# Altium schematic sheet Smart Dustbin.SchDoc
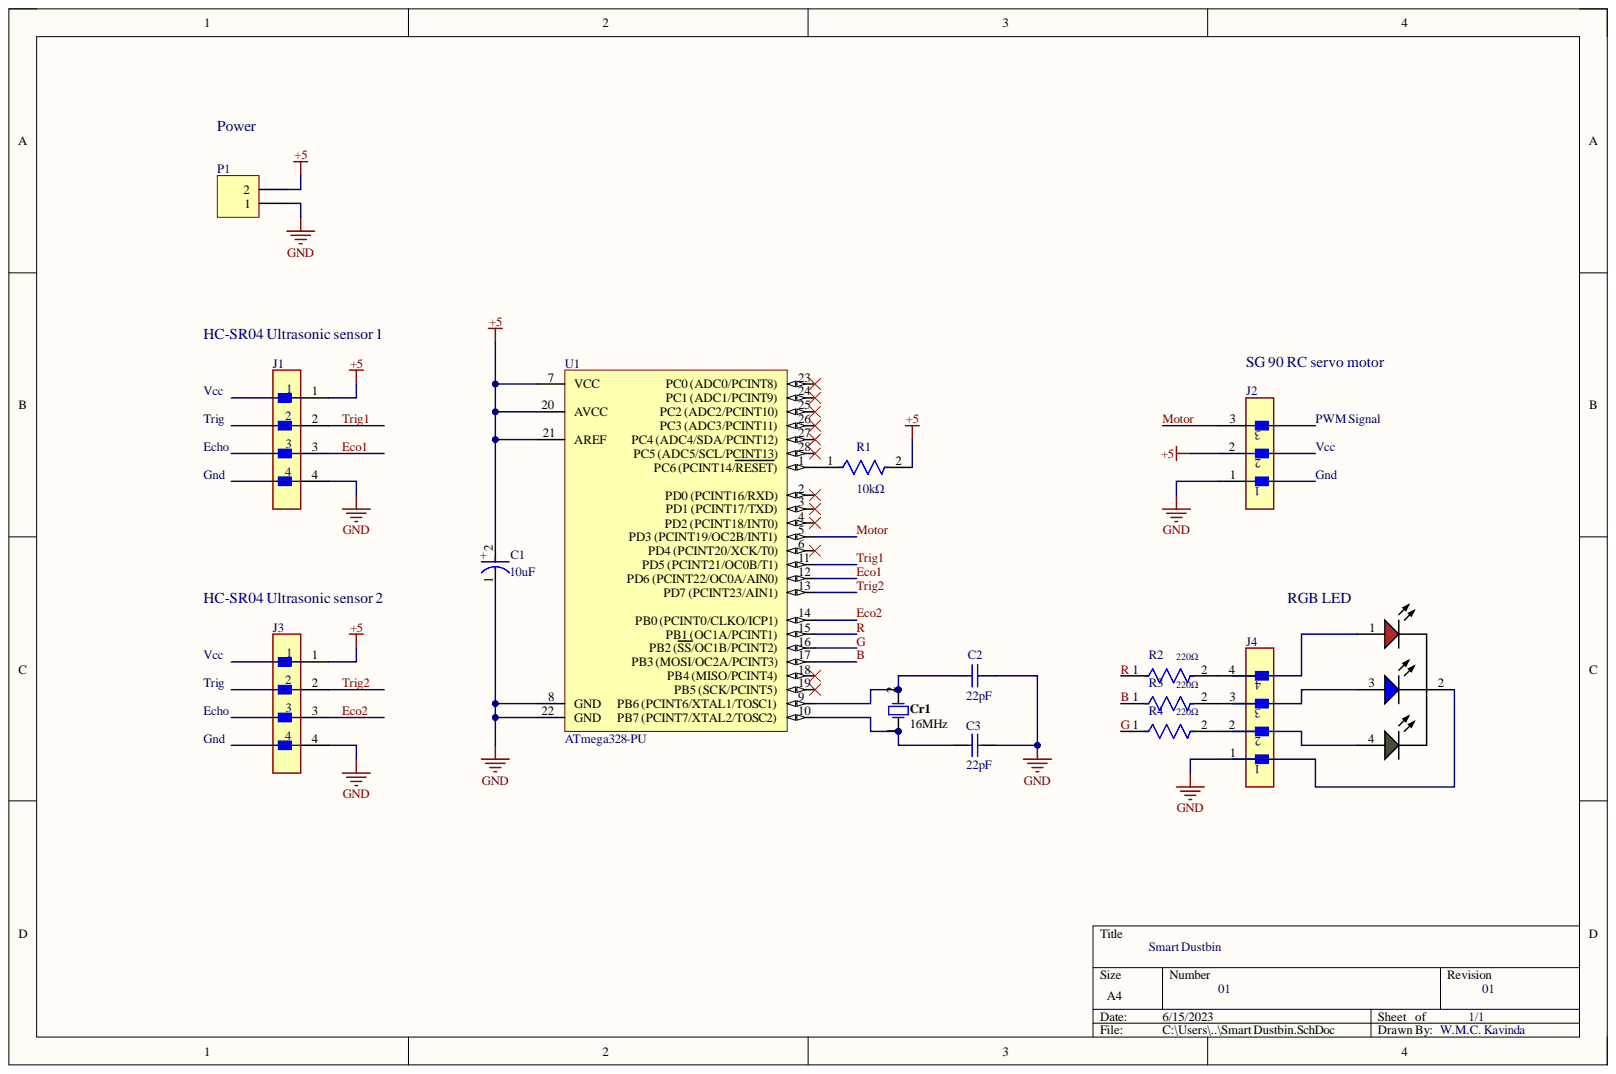
[diagram p0: Smart Dustbin.SchDoc]

## Parts
C1  10uF  [FP-RAD-TH-D_8_0_5-L_11_5-MFG]
C2  22pF  [KEMT-C315-THT-2]
C3  22pF  [KEMT-C315-THT-2]
Cr1  16MHz  [FOX-FOXSLF160-20]
DS1  WP154A4SUREQBFZGC  [KING-WP154A4SUREQBFZGC_V]  (value not drawn)
J1  TMM-104-01-S-S  [FP-TMM-104-01-S-S-MFG]  (value not drawn)
J2  TMM-103-03-T-S  [FP-TMM-103-03-T-S-MFG]  (value not drawn)
J3  TMM-104-01-S-S  [FP-TMM-104-01-S-S-MFG]  (value not drawn)
J4  TMM-104-01-S-S  [FP-TMM-104-01-S-S-MFG]  (value not drawn)
P1  Header 2  [HDR1X2]  (value not drawn)
R1  value 10kΩ  Resistance: 10kΩ  [FP-CF18-MFG]  (hidden comment: 10K)
R2  220Ω  [FP-MBA0204-MFG]
R3  220Ω  [FP-MBA0204-MFG]
R4  220Ω  [FP-MBA0204-MFG]
U1  ATmega328-PU  [28P3]

## Nets
+5: C1.2 J1.1 J2.2 J3.1 P1.2 R1.2 U1.7(VCC) U1.20(AVCC) U1.21(AREF)
GND: C1.1 C2.2 C3.2 J1.4 J2.1 J3.4 J4.1 P1.1 U1.8(GND) U1.22(GND)
B: R3.1 U1.17(PB3_(MOSI/OC2A/PCINT3))
Eco1: J1.3 U1.12(PD6_(PCINT22/OC0A/AIN0))
Eco2: J3.3 U1.14(PB0_(PCINT0/CLKO/ICP1))
G: R4.1 U1.16(PB2_(SS/OC1B/PCINT2))
Motor: J2.3 U1.5(PD3_(PCINT19/OC2B/INT1))
R: R2.1 U1.15(PB1_(OC1A/PCINT1))
Trig1: J1.2 U1.11(PD5_(PCINT21/OC0B/T1))
Trig2: J3.2 U1.13(PD7_(PCINT23/AIN1))
NetC2_1: C2.1 Cr1.2 U1.9
NetC3_1: C3.1 Cr1.1 U1.10
NetJ4_2: J4.2 R4.2
NetJ4_3: J4.3 R3.2
NetJ4_4: J4.4 R2.2
NetR1_1: R1.1 U1.1(PC6_(PCINT14/RESET))

Not connected: DS1 1 2 3 4; U1 2(PD0_(PCINT16/RXD)) 3(PD1_(PCINT17/TXD)) 4(PD2_(PCINT18/INT0)) 6(PD4_(PCINT20/XCK/T0)) 18(PB4_(MISO/PCINT4)) 19(PB5_(SCK/PCINT5)) 23(PC0_(ADC0/PCINT8)) 24(PC1_(ADC1/PCINT9)) 25 26(PC3_(ADC3/PCINT11)) 27 28(PC5_(ADC5/SCL/PCINT13))

## Library data of the parts
C1 = CMP-05427-000566-1: Aluminum Electrolytic Capacitors (Radial Lead Type) AEC-Q200 Qualified 10uF ±20% 50V; ESR (Equivalent Series Resistance): 950mR; Lead Diameter: 0.6mm; Life (Hours): 2000Hour; Ripple Current: 180mA; Capacitance: 10uF; Case/Package: Radial; Component Kind: Standard; Construction: RADIAL; Diameter: 8mm; Height: 11.5mm; Height - Seated (Max): 0.492inch; Impedance: 950mR; Lead Pitch: 3.5mm; Length: 11.5mm; Library Name: Altium Content Vault; Max Operating Temperature: 125°C; Min Operating Temperature: -40°C; Mount: Through Hole; Number of Pins: 2; Package Quantity: 200; Packaging: Bulk; Radiation Hardening: No; REACH SVHC: No SVHC; RoHS Compliant: Yes; Series: TA; Termination: Radial; Tolerance: 20%; Voltage Rating: 50V; Voltage Rating (DC): 50V
C2 C3 = CMP-1664-00008-1: Capacitor, Ceramic, 22 pF, +/-10 %, 100 V, -55 to 125 degC, 2-Pin THD, RoHS, Bulk; Case\Package: TO-220; Max Input Voltage: 40 V; Max Ouput Current: 1.5 A; Min Input Voltage: 4.2 V; Output Voltage: 1.2 V; Outputs: 1; Component Kind: Standard; ComponentLink1Description: Manufacturer URL; ComponentLink1URL: http://www.kemet.com/; ComponentLink2Description: Datasheet; ComponentLink2URL: http://capacitoredge.kemet.com/capedge2/DataSheet/Datasheet-C315C220K1G5TA.pdf?pn=C315C220K1G5TA{BULK}; DatasheetVersion: Aug-16; Height: 9.28 mm; Length: 10.28 mm; Library Name: Altium Content Vault; Max Operating Temperature: 125 degC; Min Operating Temperature: 0 degC; Mount: Through Hole; Output Current: 1.5 A; Package Quantity: 50; PackageDescription: 2-Pin Through Hole Device, Body 3.81 x 2.54 mm; PackageReference: C315-THT-2; Packaging: Rail/Tube; Pins: 3; Radiation Hardening: No; REACH SVHC: No SVHC; RoHSCompliant: true; Weight: 0.08113 oz; Width: 4.82 mm
Cr1 = CMP-1749-00003-1: Resistance Weld Thru-Hole Crystal, 16 MHz, -20 to 70 degC, 2-Pin THD, RoHS, Bulk; Case\Package: Axial; Power Rating: 250 mW; Resistance: 221 kohm; Tolerance: 1%; Voltage Rating: 250 V; Component Kind: Standard; ComponentLink1Description: Manufacturer URL; ComponentLink1URL: http://www.foxonline.com/; ComponentLink2Description: Datasheet; ComponentLink2URL: http://www.foxonline.com/pdfs/hc49slf.pdf; DatasheetVersion: Mar-15; Length: 0.248 inch; Library Name: Altium Content Vault; Max Operating Temperature: 155 degC; Min Operating Temperature: -55 degC; Mount: Through Hole; Package Quantity: 200; PackageDescription: 2-Pin Through Hole Device, Body 11.35 x 4.65 mm; PackageReference: FOXSLF160-20; Packaging: Bulk; RoHSCompliant: true
DS1 = CMP-2000-07415-1: Full Color LED Lamp, Dominant Wavelength RGB 630 / 525 / 465 nm, -40 to 85 degC, 4-Pin THD, RoHS; Manufacturer: Kingbright; 3D Model: WP154A4SUREQBFZGW.sldprt    WP154A4SUREQBFZGW.pro    WP154A4SUREQBFZGW.igs    WP154A4SUREQBFZGW.stp; Color: Red,Green,Blue(RGB); Current - Test: 20mA; Lens Style/Size: RoundwithDomedTop,5mm,T-13/4; Lens Type: Clear; Millicandela Rating: 1300mcdRed,1700mcdGreen,900mcdBlue; Online Catalog: 5mm,T-13/4(RGB)RoundwithDomedTopLEDLamp; Other Names: 754-1615  WP154A4SUREQBFZGC-ND; Viewing Angle: 50°; Voltage - Forward (Vf) (Typ): 1.9VRed,3.3VGreen,3.3VBlue; Wavelength - Dominant: 630nm,525nm,465nm; Wavelength - Peak: 645nm,515nm,460nm; Category: Optoelectronics; Component Kind: Standard; Datasheet URL: https://www.kingbrightusa.com/images/catalog/spec/WP154A4SUREQBFZGC.pdf; Datasheet Version: Rev. 5A, 08/2014; Family: LEDIndication-Discrete; Height: 8.60mm; Library Name: Altium Content Vault; Manufacturer URL: http://www.kingbright.com/; Mounting Technology: ThroughHole; Mounting Type: ThroughHole; Package / Case: Radial-4Leads; Package Description: 4-Pin Through Hole LED, Dia 5.9 mm, Pitch 1.27 mm; Package Reference: WP154A4SUREQBFZGC; Packaging: Bulk; Standard Package: 500
J1 J3 J4 = CMP-02766-001674-1: CONN HEADER VERT 4POS 2MM; Connector Type: Male Pin; Gender: Male; Max Current Rating: 3.9A; Max Voltage Rating (AC): 500V; Number of Contacts: 4; Color: Black; Component Kind: Standard; Contact Material: Bronze; Contact Mating Length: 0.126inch; Contact Plating: Gold; Contact Type: Male Pin; Depth: 1.97mm; Housing Color: Black; Housing Material: Polymer; Lead Free: Lead Free; Length: 8mm; Library Name: Altium Content Vault; Max Operating Temperature: 125°C; Min Operating Temperature: -55°C; Mount: Through Hole; Number of Positions: 4; Number of Rows: 1; Orientation: Straight; Package Quantity: 1; Packaging: Bulk; Pitch: 2mm; Radiation Hardening: No; RoHS Compliant: Yes; Series: FleXYZ™ TMM; Termination: Solder; Width: 1.97mm
J2 = CMP-02766-001695-1: CONN HEADER VERT 3POS 2MM; Gender: Male; Max Current Rating: 3.9A; Max Voltage Rating (AC): 500V; Number of Contacts: 3; Color: Black; Component Kind: Standard; Connector Type: Header; Contact Finish - Mating: TIN; Contact Material: Bronze; Contact Mating Length: 0.157inch; Contact Plating: Tin; Contact Shape: Square; Contact Type: Male Pin; Depth: 1.97mm; Fastening Type: Pull; Housing Color: Black; Housing Material: Polymer; Insulation Color: Black; Insulation Material: Polymer; Lead Free: Lead Free; Length: 6mm; Library Name: Altium Content Vault; Max Operating Temperature: 105°C; Min Operating Temperature: -55°C; Mount: Through Hole; Number of Positions: 3; Number of Rows: 1; Orientation: Straight; Package Quantity: 1; Packaging: Bulk; Pitch: 2mm; Radiation Hardening: No; REACH SVHC: No SVHC; RoHS Compliant: Yes; Series: TMM; Termination: Solder
P1 = Header 2: Header, 2-Pin; Component Kind: Standard; LatestRevisionDate: 17-Jul-2002; LatestRevisionNote: Re-released for DXP Platform.; Library Name: Miscellaneous Connectors.IntLib; Signal Integrity: Connector; Publisher: Altium Limited
R1 = CMP-26528-000002-1: RES 10K OHM 1/8W 5% CF AXIAL; Manufacturer: Stackpole Electronics; Manufacturer Part Number: CF18JT10K0; Power Rating: 0.125W; Temperature Coefficient: 0-500ppm/°C; Voltage Rating: 250V; Automotive: No; Category: Res; Component Kind: Standard; ComponentLink2Description: Datasheet; ComponentLink2URL: http://www.seielect.com/Catalog/SEI-CF_CFM.pdf; Composition: Carbon Film; Device Class L1: Passive Components; Device Class L2: Resistors; Device Class L3: Through-Hole Resistors; Digikey Description: RES 10K OHM 1/8W 5% CF AXIAL; Height: 2mm; Ipc Land Pattern Name: RESAD656W65L360D200; Lead Free: Yes; Library Name: Altium Content Vault; Octopart: https://octopart.com/cf18jt10k0-stackpole+electronics-19205642; Package: CF18; RoHS: TRUE; Series: CF; Temperature: -55°C to +155°C; Temperature Range High: +155°C; Temperature Range Low: -55°C; Tolerance: 5%
R2-R4 = CMP-02408-000005-1: RES 220 OHM 0.4W 1% AXIAL; Manufacturer: Vishay BC Components; Manufacturer Part Number: MBA02040C2200FC100; Automotive Grade: Grade 1; Power Rating: 0.25W; Temperature Coefficient: 50ppm/°C; Voltage Rating: 200V; Automotive: Yes; Category: Res; Component Kind: Standard; ComponentLink2Description: Datasheet; ComponentLink2URL: http://www.vishay.com/docs/28766/mbxsma.pdf; Composition: Metal Film; Device Class L1: Passive Components; Device Class L2: Resistors; Device Class L3: Through-Hole Resistors; Digikey Description: RES 220 OHM 0.4W 1% AXIAL; Height: 1.6mm; Ipc Land Pattern Name: RESAD500W65L360D160; Lead Free: Yes; Library Name: Altium Content Vault; Mouser Description: Thin Film Resistors - Through Hole .4watt 220ohms 1% 1/8watt body size; Octopart: https://octopart.com/mba02040c2200fc100-vishay-43743094; Package: MBA0204; Resistance: 220Ω; RoHS: TRUE; Series: MBA/SMA 0204; Temperature: -55°C to +125°C; Temperature Range High: +125°C; Temperature Range Low: -55°C; Tolerance: 1%; Value: 220Ω
U1 = CMP-0095-00015-2: 8-bit AVR Microcontroller, 32KB Flash, 1KB EEPROM, 2KB SRAM, 28-pin PDIP, Industrial Grade (-40°C to 85°C); Component Kind: Standard; ComponentLink1Description: Manufacturer URL; ComponentLink1URL: http://www.atmel.com/; ComponentLink2Description: ATmega48A/48PA/88A/88PA/168A/168PA/328/328P Datasheet; ComponentLink2URL: http://www.atmel.com/dyn/resources/prod_documents/8271.pdf; ComponentLink3Description: ATmega48A/48PA/88A/88PA/168A/168PA/328/328P Summary; ComponentLink3URL: http://www.atmel.com/dyn/resources/prod_documents/8271S.pdf; DatasheetVersion: revD, May-2011; Library Name: Altium Content Vault; PackageDescription: 28-lead (0.300"/7.62mm Wide) Plastic Dual Inline Package (PDIP); PackageReference: 28P3; PackageVersion: revB, Sep-2001; RevisionNote: DatasheetVersion updated. URLs added.
